# Altium schematic sheet (SCH)SK-HC32F146-64L-MCU.SchDoc((SCH)SK-HC32F146-64L-MCU)
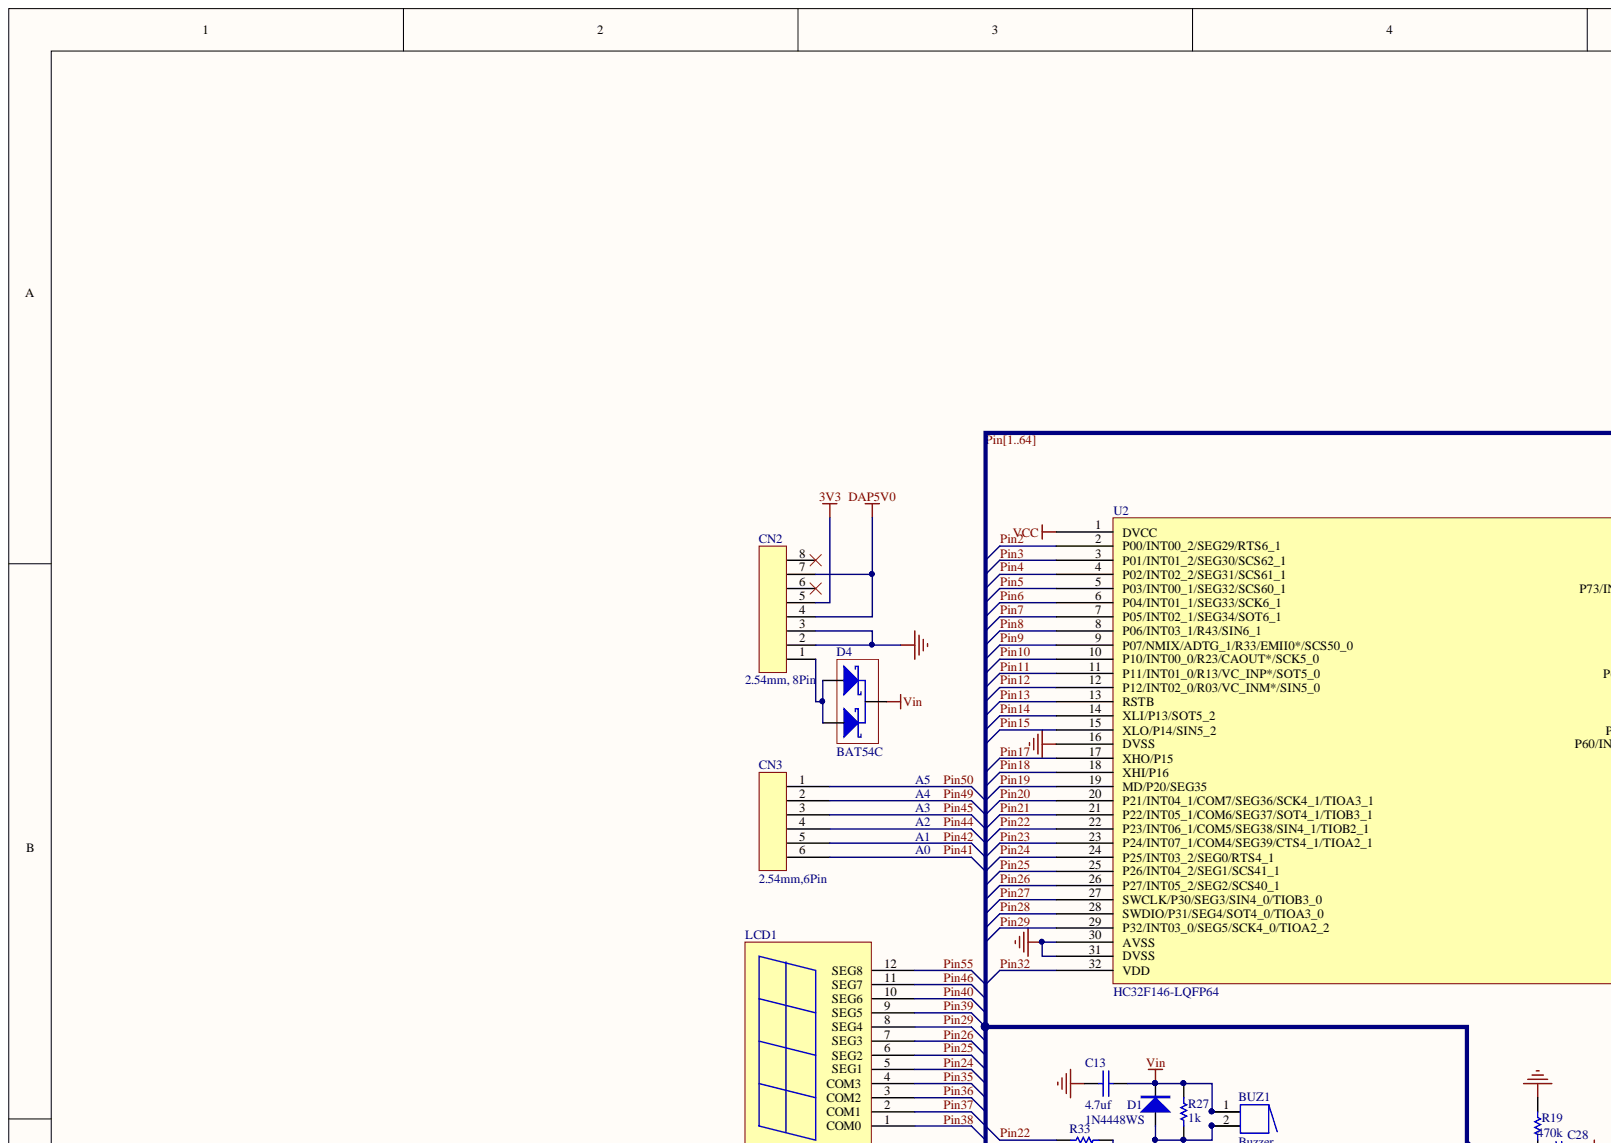
[diagram p0: , top left]
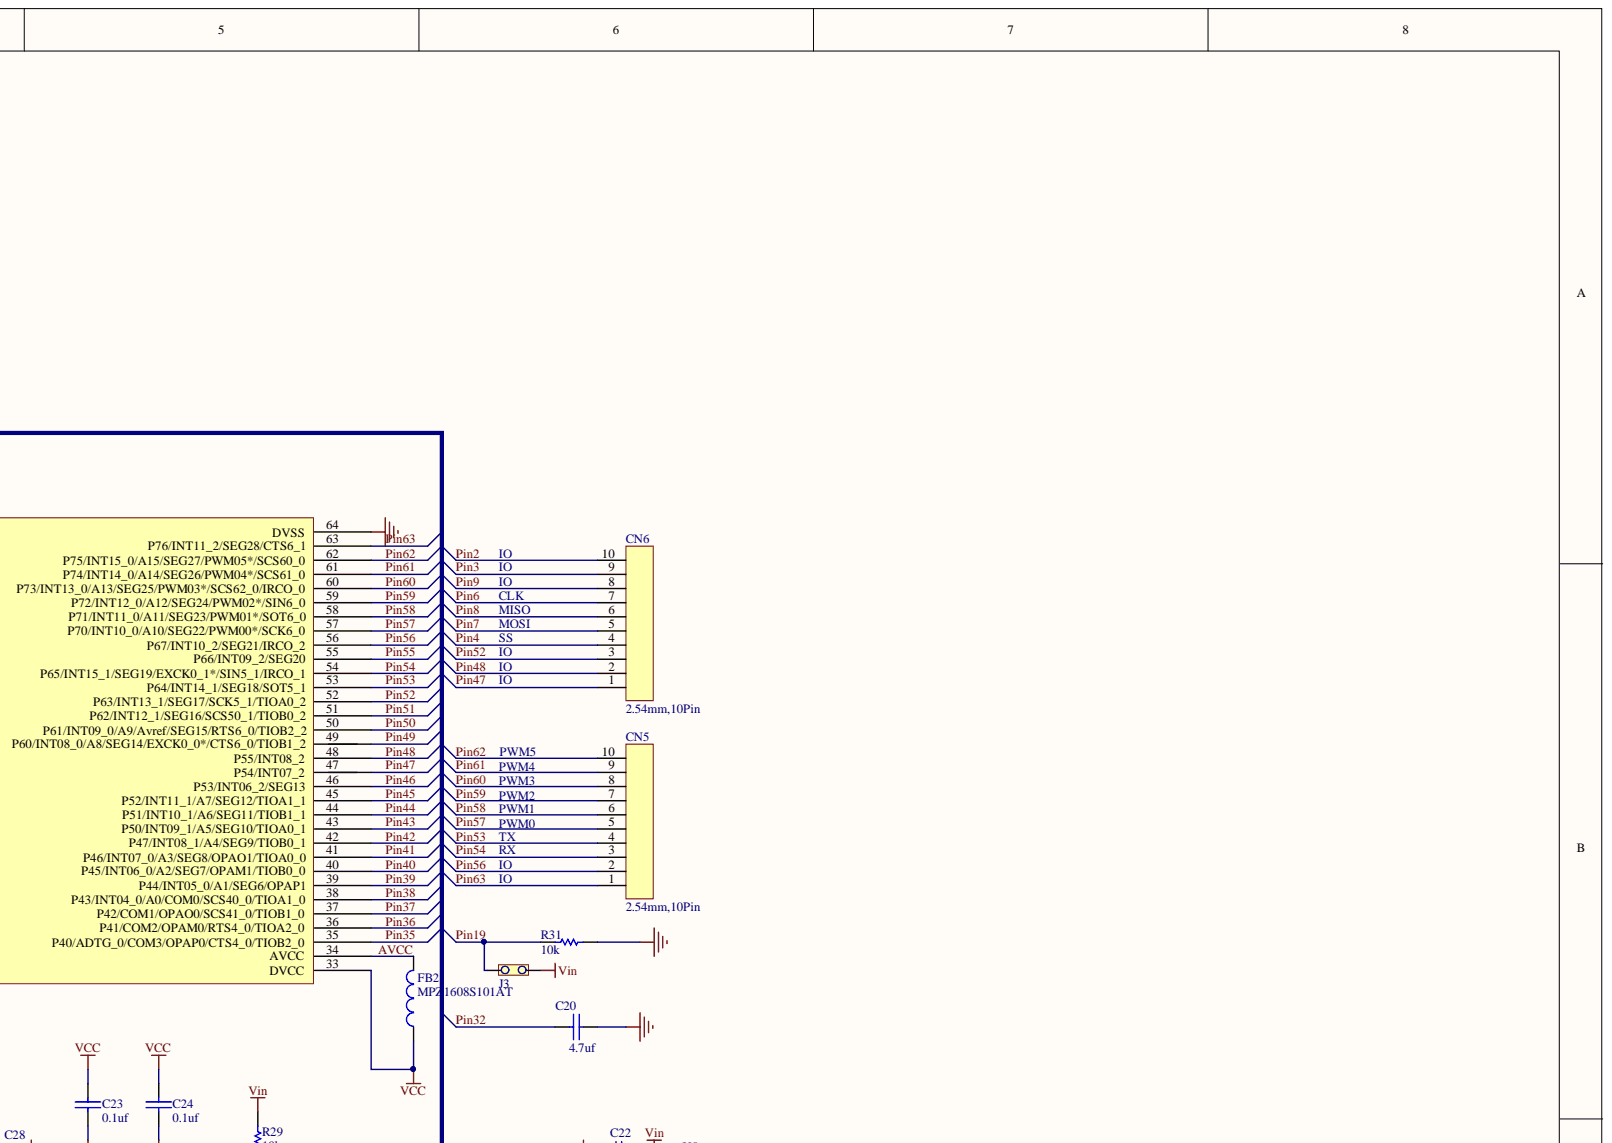
[diagram p1: , top right]
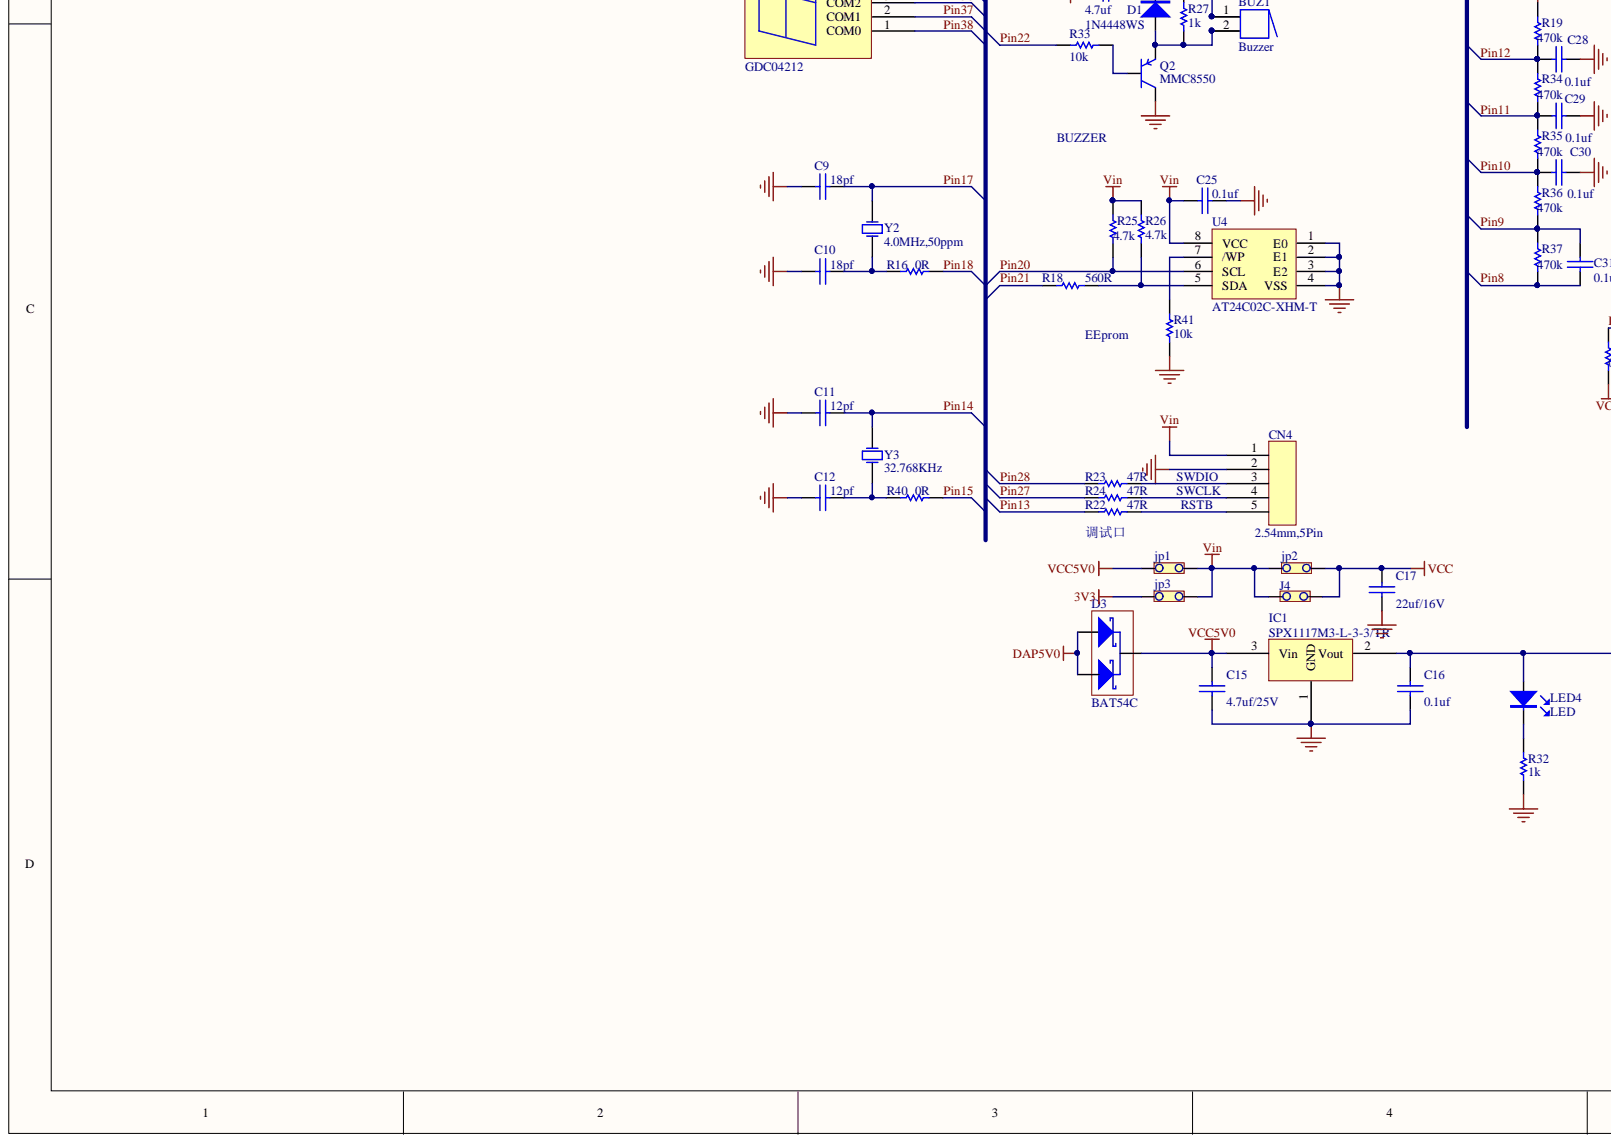
[diagram p2: , bottom left]
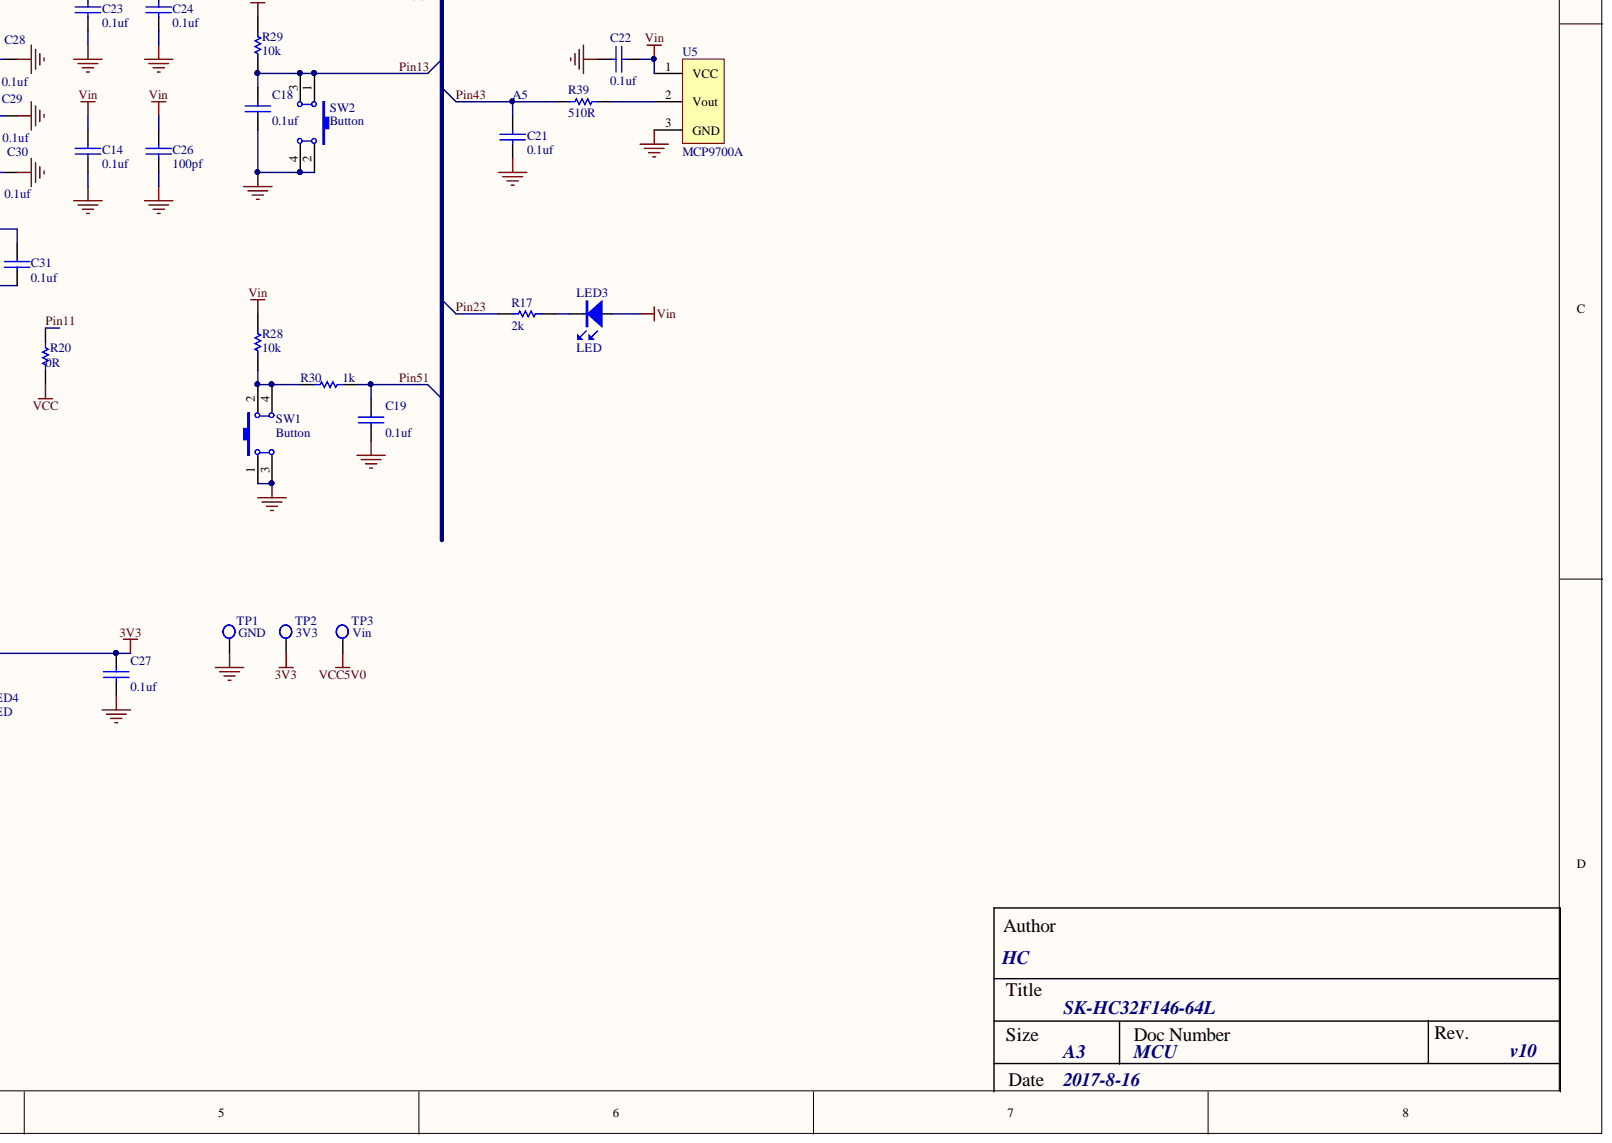
[diagram p3: , bottom right]

## Parts
BUZ1  Buzzer  [Buzzer]
C9  18pf  [c0603]
C10  18pf  [c0603]
C11  12pf  [c0603]
C12  12pf  [c0603]
C13  4.7uf  [c0805]
C14  0.1uf  [c0603]
C15  4.7uf/25V  [c0805]
C16  0.1uf  [c0603]
C17  22uf/16V  [c1206]
C18  0.1uf  [c0603]
C19  0.1uf  [c0603]
C20  4.7uf  [c0805]
C21  0.1uf  [c0603]
C22  0.1uf  [c0603]
C23  0.1uf  [c0603]
C24  0.1uf  [c0603]
C25  0.1uf  [c0603]
C26  100pf  [c0603]
C27  0.1uf  [c0603]
C28  0.1uf  [c0603]
C29  0.1uf  [c0603]
C30  0.1uf  [c0603]
C31  0.1uf  [c0603]
CN2  2.54mm, 8Pin  [PIN-8-254-S]
CN3  2.54mm,6Pin  [PIN-6-254-S]
CN4  2.54mm,5Pin  [PIN-5-254-S]
CN5  2.54mm,10Pin  [PIN-10-254-S]
CN6  2.54mm,10Pin  [PIN-10-254-S]
D1  1N4448WS  [SOD-323]
D3  BAT54C  [SOT-23]
D4  BAT54C  [SOT-23]
FB2  MPZ1608S101AT  [R0603]
IC1  SPX1117M3-L-3-3/TR  [SOT-223]
J3  2.54mm,2pins  [JMP-2.54]  (value not drawn)
J4  2.54mm,2pins  [JMP-2.54]  (value not drawn)
jp1  jumper wire  [jpo-0603]  (value not drawn)
jp2  jumper wire  [jpo-0603]  (value not drawn)
jp3  jumper wire  [jpo-0603]  (value not drawn)
LCD1  GDC04212  [GDC04212]
LED3  LED  [LED0603]
LED4  LED  [LED0603]
Q2  MMC8550  [SOT-23]
R16  0R  [R0603]
R17  2k  [R0603]
R18  560R  [R0603]
R19  470k  [R0603]
R20  0R  [R0603]
R22  47R  [R0603]
R23  47R  [R0603]
R24  47R  [R0603]
R25  4.7k  [R0603]
R26  4.7k  [R0603]
R27  1k  [R0603]
R28  10k  [R0603]
R29  10k  [R0603]
R30  1k  [R0603]
R31  10k  [R0603]
R32  1k  [R0603]
R33  10k  [R0603]
R34  470k  [R0603]
R35  470k  [R0603]
R36  470k  [R0603]
R37  470k  [R0603]
R39  510R  [R0603]
R40  0R  [R0603]
R41  10k  [R0603]
SW1  Button  [PUSHBUTTON]
SW2  Button  [PUSHBUTTON]
TP1  GND  [TP]
TP2  3V3  [TP]
TP3  Vin  [TP]
U2  HC32F146-LQFP64  [LC-LQFP-64_10x10x05P]
U4  AT24C02C-XHM-T  [TSSOP-8-0.65]
U5  MCP9700A  [SOT-23]
Y2  4.0MHz,50ppm  [49S]
Y3  32.768KHz  [32.768K]

## Nets
3V3: C16.2 C27.2 CN2.5 IC1.2 jp3.1 LED4.1 TP2.1
DAP5V0: CN2.4 CN2.7 D3.1 D3.2
GND: C9.2 C10.2 C11.2 C12.2 C13.1 C14.2 C15.1 C16.1 C17.1 C18.1 C19.1 C20.2 C21.2 C22.2 C23.2 C24.2 C25.1 C26.2 C27.1 C28.2 C29.2 C30.2 CN2.2 CN2.3 CN4.2 IC1.1 Q2.3 R19.2 R31.2 R32.2 R41.1 SW1.1 SW1.3 SW2.2 SW2.4 TP1.1 U2.16(DVSS) U2.30(AVSS) U2.31(DVSS) U2.64(DVSS) U4.1(E0) U4.2(E1) U4.3(E2) U4.4(VSS) U5.3
VCC: C17.2 C23.1 C24.1 FB2.1 J4.2 jp2.2 R20.1 U2.1(DVCC) U2.33(DVCC)
VCC5V0: C15.2 D3.3 IC1.3 jp1.1 TP3.1
Vin: BUZ1.1 BUZ1.1A C13.2 C14.1 C22.1 C25.2 C26.1 CN4.1 D1.1 D4.3 J3.2 J4.1 jp1.2 jp2.1 jp3.2 LED3.1 R25.2 R26.2 R27.2 R28.2 R29.2 U4.8(VCC) U5.1
AVCC: FB2.2 U2.34(AVCC)
Pin2: CN6.10 U2.2(P00/INT00_2/SEG29/RTS6_1)  [also labelled PIN]
Pin3: CN6.9 U2.3(P01/INT01_2/SEG30/SCS62_1)  [also labelled PIN]
Pin4: CN6.4 U2.4(P02/INT02_2/SEG31/SCS61_1)  [also labelled PIN]
Pin5: U2.5(P03/INT00_1/SEG32/SCS60_1)  [also labelled PIN]
Pin6: CN6.7 U2.6(P04/INT01_1/SEG33/SCK6_1)  [also labelled PIN]
Pin7: CN6.5 U2.7(P05/INT02_1/SEG34/SOT6_1)  [also labelled PIN]
Pin8: C31.2 CN6.6 R37.1 U2.8(P06/INT03_1/R43/SIN6_1)  [also labelled PIN]
Pin9: C31.1 CN6.8 R36.1 R37.2 U2.9(P07/NMIX/ADTG_1/R33/EMII0*/SCS50_0)  [also labelled PIN]
Pin10: C30.1 R35.1 R36.2 U2.10(P10/INT00_0/R23/CAOUT*/SCK5_0)  [also labelled PIN]
Pin11: C29.1 R20.2 R34.1 R35.2 U2.11(P11/INT01_0/R13/VC_INP*/SOT5_0)  [also labelled PIN]
Pin12: C28.1 R19.1 R34.2 U2.12(P12/INT02_0/R03/VC_INM*/SIN5_0)  [also labelled PIN]
Pin13: C18.2 R22.1 R29.1 SW2.1 SW2.3 U2.13(RSTB)  [also labelled PIN]
Pin14: C11.1 U2.14(XLI/P13/SOT5_2) Y3.2  [also labelled PIN]
Pin15: R40.2 U2.15(XLO/P14/SIN5_2)  [also labelled PIN]
Pin17: C9.1 U2.17(XHO/P15) Y2.2  [also labelled PIN]
Pin18: R16.2 U2.18(XHI/P16)  [also labelled PIN]
Pin19: J3.1 R31.1 U2.19(MD/P20/SEG35)  [also labelled PIN]
Pin20: R25.1 U2.20(P21/INT04_1/COM7/SEG36/SCK4_1/TIOA3_1) U4.6(SCL)  [also labelled PIN]
Pin21: R18.1 U2.21(P22/INT05_1/COM6/SEG37/SOT4_1/TIOB3_1)  [also labelled PIN]
Pin22: R33.2 U2.22(P23/INT06_1/COM5/SEG38/SIN4_1/TIOB2_1)  [also labelled PIN]
Pin23: R17.2 U2.23(P24/INT07_1/COM4/SEG39/CTS4_1/TIOA2_1)  [also labelled PIN]
Pin24: LCD1.5(SEG1) U2.24(P25/INT03_2/SEG0/RTS4_1)  [also labelled PIN]
Pin25: LCD1.6(SEG2) U2.25(P26/INT04_2/SEG1/SCS41_1)  [also labelled PIN]
Pin26: LCD1.7(SEG3) U2.26(P27/INT05_2/SEG2/SCS40_1)  [also labelled PIN]
Pin27: R24.1 U2.27(SWCLK/P30/SEG3/SIN4_0/TIOB3_0)  [also labelled PIN]
Pin28: R23.1 U2.28(SWDIO/P31/SEG4/SOT4_0/TIOA3_0)  [also labelled PIN]
Pin29: LCD1.8(SEG4) U2.29(P32/INT03_0/SEG5/SCK4_0/TIOA2_2)  [also labelled PIN]
Pin32: C20.1 U2.32(VDD)  [also labelled PIN]
Pin35: LCD1.4(COM3) U2.35(P40/ADTG_0/COM3/OPAP0/CTS4_0/TIOB2_0)  [also labelled PIN]
Pin36: LCD1.3(COM2) U2.36(P41/COM2/OPAM0/RTS4_0/TIOA2_0)  [also labelled PIN]
Pin37: LCD1.2(COM1) U2.37(P42/COM1/OPAO0/SCS41_0/TIOB1_0)  [also labelled PIN]
Pin38: LCD1.1(COM0) U2.38(P43/INT04_0/A0/COM0/SCS40_0/TIOA1_0)  [also labelled PIN]
Pin39: LCD1.9(SEG5) U2.39(P44/INT05_0/A1/SEG6/OPAP1)  [also labelled PIN]
Pin40: LCD1.10(SEG6) U2.40(P45/INT06_0/A2/SEG7/OPAM1/TIOB0_0)  [also labelled PIN]
Pin41: CN3.6 U2.41(P46/INT07_0/A3/SEG8/OPAO1/TIOA0_0)  [also labelled PIN]
Pin42: CN3.5 U2.42(P47/INT08_1/A4/SEG9/TIOB0_1)  [also labelled PIN]
Pin43: C21.1 R39.2 U2.43(P50/INT09_1/A5/SEG10/TIOA0_1)  [also labelled PIN]
Pin44: CN3.4 U2.44(P51/INT10_1/A6/SEG11/TIOB1_1)  [also labelled PIN]
Pin45: CN3.3 U2.45(P52/INT11_1/A7/SEG12/TIOA1_1)  [also labelled PIN]
Pin46: LCD1.11(SEG7) U2.46(P53/INT06_2/SEG13)  [also labelled PIN]
Pin47: CN6.1 U2.47(P54/INT07_2)  [also labelled PIN]
Pin48: CN6.2 U2.48(P55/INT08_2)  [also labelled PIN]
Pin49: CN3.2 U2.49(P60/INT08_0/A8/SEG14/EXCK0_0*/CTS6_0/TIOB1_2)  [also labelled PIN]
Pin50: CN3.1 U2.50(P61/INT09_0/A9/Avref/SEG15/RTS6_0/TIOB2_2)  [also labelled PIN]
Pin51: C19.2 R30.2 U2.51(P62/INT12_1/SEG16/SCS50_1/TIOB0_2)  [also labelled PIN]
Pin52: CN6.3 U2.52(P63/INT13_1/SEG17/SCK5_1/TIOA0_2)  [also labelled PIN]
Pin53: CN5.4 U2.53(P64/INT14_1/SEG18/SOT5_1)  [also labelled PIN]
Pin54: CN5.3 U2.54(P65/INT15_1/SEG19/EXCK0_1*/SIN5_1/IRCO_1)  [also labelled PIN]
Pin55: LCD1.12(SEG8) U2.55(P66/INT09_2/SEG20)  [also labelled PIN]
Pin56: CN5.2 U2.56(P67/INT10_2/SEG21/IRCO_2)  [also labelled PIN]
Pin57: CN5.5 U2.57(P70/INT10_0/A10/SEG22/PWM00*/SCK6_0)  [also labelled PIN]
Pin58: CN5.6 U2.58(P71/INT11_0/A11/SEG23/PWM01*/SOT6_0)  [also labelled PIN]
Pin59: CN5.7 U2.59(P72/INT12_0/A12/SEG24/PWM02*/SIN6_0)  [also labelled PIN]
Pin60: CN5.8 U2.60(P73/INT13_0/A13/SEG25/PWM03*/SCS62_0/IRCO_0)  [also labelled PIN]
Pin61: CN5.9 U2.61(P74/INT14_0/A14/SEG26/PWM04*/SCS61_0)  [also labelled PIN]
Pin62: CN5.10 U2.62(P75/INT15_0/A15/SEG27/PWM05*/SCS60_0)  [also labelled PIN]
Pin63: CN5.1 U2.63(P76/INT11_2/SEG28/CTS6_1)  [also labelled PIN]
NetBUZ1_2: BUZ1.2 BUZ1.2A D1.2 Q2.2 R27.1
NetC10_1: C10.1 R16.1 Y2.1
NetC12_1: C12.1 R40.1 Y3.1
NetCN2_1: CN2.1 D4.1 D4.2
NetCN4_3: CN4.3 R23.2
NetCN4_4: CN4.4 R24.2
NetCN4_5: CN4.5 R22.2
NetLED3_2: LED3.2 R17.1
NetLED4_2: LED4.2 R32.1
NetQ2_1: Q2.1 R33.1
NetR18_2: R18.2 R26.1 U4.5(SDA)
NetR28_1: R28.1 R30.1 SW1.2 SW1.4
NetR39_1: R39.1 U5.2
NetR41_2: R41.2 U4.7(/WP)
N00180:
N00181:
Pin1:  [also labelled PIN]
Pin16:  [also labelled PIN]
Pin30:  [also labelled PIN]
Pin31:  [also labelled PIN]
Pin33:  [also labelled PIN]
Pin34:  [also labelled PIN]
Pin64:  [also labelled PIN]

Not connected: CN2 6 8

## Library data of the parts
C9-C12 C14 C16 C18 C19 C21-C31 = CAP-CC(SMD): Ceramic Capacitor,0603; Library Name: MyLib_Altium.SCHLIB
  C9-C12 C14 C16 C18 C19 C21-C27: Component Kind: Standard
  C28-C31: Component Kind: Net Tie
C13 C15 C20 = CAP-CC(SMD): Ceramic Capacitor,0805; Library Name: MyLib_Altium.SCHLIB; Component Kind: Standard
C17 = CAP-CC(SMD): Ceramic Capacitor,1206; Library Name: MyLib_Altium.SCHLIB; Component Kind: Standard
CN2 = PIN-1-8-254: Arduino header; Library Name: MyLib_Altium.SCHLIB; Component Kind: Standard
CN3 = PIN-1-6-254: Value: 2.54mm,4pin; Library Name: MyLib_Altium.SCHLIB; Component Kind: Standard
CN4 = PIN-1-5-254: 插针; Value: 2.54mm,4pin; Library Name: MyLib_Altium.SCHLIB; Component Kind: Standard
CN5 CN6 = PIN-1-10-254: Arduino header; Library Name: MyLib_Altium.SCHLIB; Component Kind: Standard
D1 = DIODE: Text Field1: 4007; Library Name: MyLib_Altium.SCHLIB; Component Kind: Standard
D3 D4 = BAT54C: Dual schotty diode, ON semi; Library Name: MyLib_Altium.SCHLIB; Component Kind: Standard
FB2 = Inductor: Ferrite bead, 3A,100R@100MHz,TDK; Code_IEC: 1005; Simulation: INDUCTOR; Signal Integrity: Inductor; Published: 8-Jun-2000; PackageReference: 0402-A; Library Name: MyLib_Altium.SCHLIB; LatestRevisionNote: Re-released for DXP Platform.; LatestRevisionDate: 17-Jul-2002; Component Kind: Standard; Code_JEITA: 0402; Publisher: Altium Limited
IC1 = SPX1117M3-L-3-3/TR: LDO, Vout = 3V3; Library Name: MyLib_Altium.SCHLIB; Component Kind: Standard
J3 J4 = Jumper-2: 2.54mm, 2pin jumper; Published: 24-Mar-1999; PackageReference: RAD-0.2; Library Name: MyLib_Altium.SCHLIB; LatestRevisionNote: Re-released for DXP Platform.; LatestRevisionDate: 17-Jul-2002; Component Kind: Standard; Publisher: Altium Limited
jp1-jp3 = Jpo: default open jumper wire,0603; Library Name: MyLib_Altium.SCHLIB
  jp1 jp2: Component Kind: Net Tie
  jp3: Component Kind: Standard
LCD1 = GDC04212: LCD; Library Name: MyLib_Altium.SCHLIB; Component Kind: Standard
LED3 LED4 = LED: BLUE,0603,SMT; Library Name: MyLib_Altium.SCHLIB; Component Kind: Standard
Q2 = T_PNP: PNP transistor; Component Kind: Standard; Library Name: FUJITSU-SK.SCHLIB
R16 R18-R20 R22-R28 R30 R33-R37 R40 R41 = RES: Resister,0603,5%; Value: 100R; Library Name: MyLib_Altium.SCHLIB
  R16 R18 R22-R28 R30 R33 R40 R41: Component Kind: Standard
  R19 R20 R34-R37: Component Kind: Net Tie
R17 R29 R31 R32 R39 = RES: Resister,0603,5%; Library Name: MyLib_Altium.SCHLIB; Component Kind: Standard
SW1 SW2 = Button-SMD-6*6: SMD button,6*6; Library Name: MyLib_Altium.SCHLIB; Component Kind: Standard
TP1 = Testpoint: Value: GND; Library Name: MyLib_Altium.SCHLIB; Component Kind: Standard
TP2 TP3 = Testpoint: Value: GND; Library Name: MyLib_Altium.SCHLIB; Component Kind: Standard
U2 = HC32F15: 0.5mm,LQFP64,HDSC; Library Name: HC32F15X.SchLib; Component Kind: Standard
U4 = M24256: 2kB EEPROM, ST; Library Name: MyLib_Altium.SCHLIB; Component Kind: Standard
U5 = MCP9700A: Temperature Sensor,SOT-23; Library Name: MyLib_Altium.SCHLIB; Component Kind: Standard
Y2 = Crystal: 4.0000MHz; Library Name: MyLib_Altium.SCHLIB; Component Kind: Standard
Y3 = Crystal: Library Name: MyLib_Altium.SCHLIB; Description: 32.768KHz; Component Kind: Standard
BUZ1 = Buzzer: Value: Buzzer; Library Name: MyLib_Altium.SCHLIB; Component Kind: Standard
